# Altium schematic sheet ADC.SchDoc
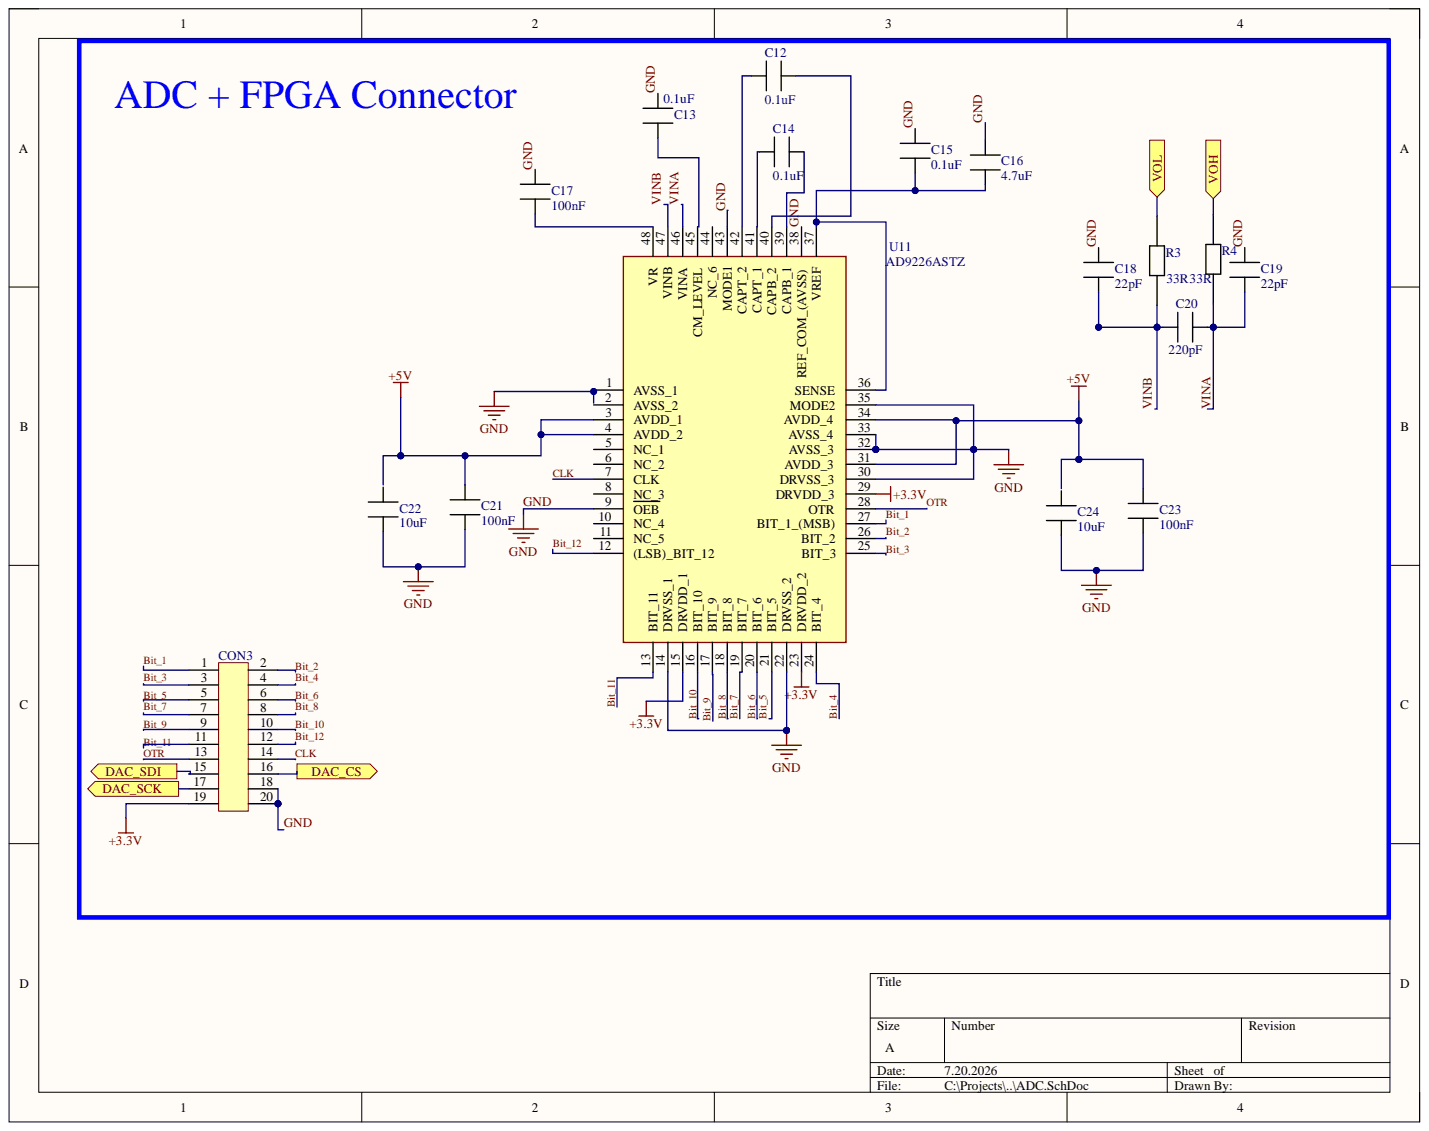
[diagram p0: ADC.SchDoc]

## Parts
C12  0.1uF  [CAP_0805]
C13  0.1uF  [CAP_0805]
C14  0.1uF  [CAP_0805]
C15  0.1uF  [CAP_0805]
C16  4.7uF  [CAP_0805]
C17  100nF  [CAP_0805]
C18  22pF  [CAP_0805]
C19  22pF  [CAP_0805]
C20  220pF  [CAP_0805]
C21  100nF  [CAP_0805]
C22  10uF  [CAP_0805]
C23  100nF  [CAP_0805]
C24  10uF  [CAP_0805]
CON3  5557/5569 connector  [CON_2x10_4.2mm_THT]  (value not drawn)
R3  33R  [RES_0805]
R4  33R  [RES_0805]
U11  AD9226ASTZ  [QFP50P900X900X160-48N]

## Ports
DAC_CS, DAC_SCK, DAC_SDI, VOH, VOL

## Nets
+3.3V: CON3.19 U11.15(DRVDD_1) U11.23(DRVDD_2) U11.29(DRVDD_3)
+5V: C21.1 C23.1 U11.3(AVDD_1) U11.4(AVDD_2) U11.31(AVDD_3) U11.34(AVDD_4)
Bit_1: CON3.1 U11.27(BIT_1_(MSB))
Bit_2: CON3.2 U11.26(BIT_2)
Bit_3: CON3.3 U11.25(BIT_3)
Bit_4: CON3.4 U11.24(BIT_4)
Bit_5: CON3.5 U11.21(BIT_5)
Bit_6: CON3.6 U11.20(BIT_6)
Bit_7: CON3.7 U11.19(BIT_7)
Bit_8: CON3.8 U11.18(BIT_8)
Bit_9: CON3.9 U11.17(BIT_9)
Bit_10: CON3.10 U11.16(BIT_10)
Bit_11: CON3.11 U11.13(BIT_11)
Bit_12: CON3.12 U11.12((LSB)_BIT_12)
CLK: CON3.14 U11.7(CLK)
DAC_CS: CON3.16 ; port DAC_CS
DAC_SCK: CON3.17 ; port DAC_SCK
DAC_SDI: CON3.15 ; port DAC_SDI
GND: C13.1 C15.1 C16.1 C17.1 C18.1 C19.1 C21.2 C22.1 C23.2 C24.1 CON3.18 CON3.20 U11.1(AVSS_1) U11.9(OEB) U11.14(DRVSS_1) U11.22(DRVSS_2) U11.30(DRVSS_3) U11.32(AVSS_3) U11.33(AVSS_4) U11.35(MODE2) U11.38(REF_COM_(AVSS)) U11.43(MODE1)
OTR: CON3.13 U11.28(OTR)
VINA: C19.2 C20.2 R4.1 U11.46(VINA)
VINB: C18.2 C20.1 R3.1 U11.47(VINB)
VOH: R4.2 ; port VOH
VOL: R3.2 ; port VOL
NetC12_1: C12.1 U11.42(CAPT_2)
NetC12_2: C12.2 U11.40(CAPB_2)
NetC13_2: C13.2 U11.45(CM_LEVEL)
NetC14_1: C14.1 U11.41(CAPT_1)
NetC14_2: C14.2 U11.39(CAPB_1)
NetC15_2: C15.2 C16.2 U11.36(SENSE) U11.37(VREF)
NetC17_2: C17.2 U11.48(VR)

Not connected: C22 2; C24 2; U11 2(AVSS_2) 5(NC_1) 6(NC_2) 8(NC_3) 10(NC_4) 11(NC_5) 44(NC_6)

## Library data of the parts
C12-C24 = CAP_0805: note: any manufacturer; Component Kind: Standard; Description: CAP_0805; Library Name: Passives.SchLib
  C12-C15: val: 0.1uF
  C16: val: 4.7uF
  C17 C21 C23: val: 100nF
  C18 C19: val: 22pF
  C20: val: 220pF
  C22 C24: val: 10uF
CON3 = CON_2x10_4.2mm_THT: CON_2x10_4.2mm_THT Straight Pin; Component Kind: Standard; Library Name: Connectors.SchLib; sample link: https://item.taobao.com/item.htm?abbucket=17&id=557446625982&ns=1&spm=a230r.1.14.26.4ae16218Zr5FXY&skuId=3456302328403; val: 5557/5569 connector
R3 R4 = RES_0805: note: any manufacturer; Component Kind: Standard; Description: RES_0805; Library Name: Passives.SchLib; val: 33R
U11 = AD9226ASTZ: AD9226ASTZ, 12 bit-Bit DAC 65Msps, Parallel, 48-Pin LQFP; Manufacturer_Name: Analog Devices; Geometry.Height: 1.6mm; Arrow Part Number: AD9226ASTZ; Arrow Price/Stock: https://www.arrow.com/en/products/ad9226astz/analog-devices?utm_currency=USD&region=nac; Component Kind: Standard; Datasheet Link: https://datasheet.datasheetarchive.com/originals/distributors/Datasheets-4/DSA-70171.pdf; Library Name: IC_Digital.SchLib; Manufacturer_Part_Number: AD9226ASTZ; Mouser Part Number: 584-AD9226ASTZ; Mouser Price/Stock: https://www.mouser.co.uk/ProductDetail/Analog-Devices/AD9226ASTZ?qs=%2FtpEQrCGXCz2C6o%252BfI8LqA%3D%3D
